# Altium schematic sheet Status_LEDS.SchDoc
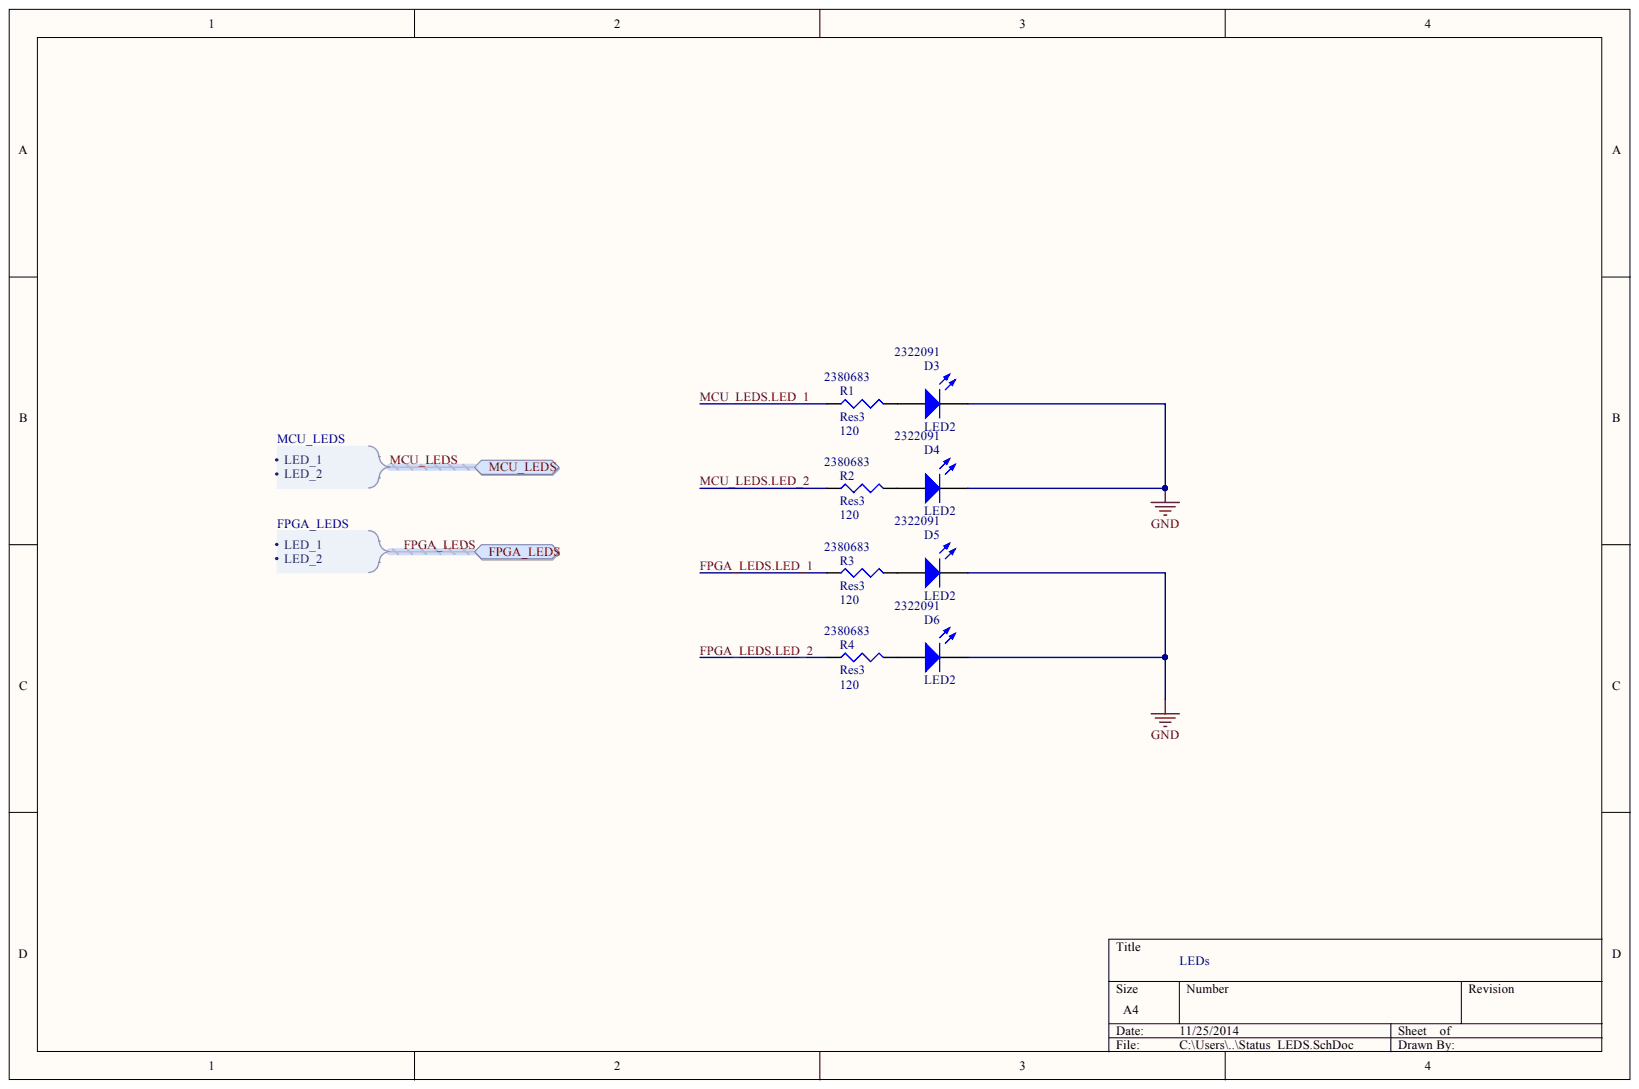
[diagram p0: Status_LEDS.SchDoc]

## Parts
D3  LED2  Supplier Part Number 1: 2322091  [C1206]
D4  LED2  Supplier Part Number 1: 2322091  [C1206]
D5  LED2  Supplier Part Number 1: 2322091  [C1206]
D6  LED2  Supplier Part Number 1: 2322091  [C1206]
R1  Res3  value 120  Supplier Part Number 1: 2380683  [14-1210]
R2  Res3  value 120  Supplier Part Number 1: 2380683  [14-1210]
R3  Res3  value 120  Supplier Part Number 1: 2380683  [14-1210]
R4  Res3  value 120  Supplier Part Number 1: 2380683  [14-1210]

## Ports
FPGA_LEDS, FPGA_LEDS.LED_1, FPGA_LEDS.LED_2, MCU_LEDS, MCU_LEDS.LED_1, MCU_LEDS.LED_2

## Nets
GND: D3.2 D4.2 D5.2 D6.2
FPGA_LEDS.LED_1: R3.1 ; port FPGA_LEDS
FPGA_LEDS.LED_2: R4.1 ; port FPGA_LEDS
MCU_LEDS.LED_1: R1.1 ; port MCU_LEDS
MCU_LEDS.LED_2: R2.1 ; port MCU_LEDS
NetD3_1: D3.1 R1.2
NetD4_1: D4.1 R2.2
NetD5_1: D5.1 R3.2
NetD6_1: D6.1 R4.2

## Library data of the parts
D3-D6 = LED2: Typical RED, GREEN, YELLOW, AMBER GaAs LED; Manufacturer: WURTH ELEKTRONIK; Manufacturer Part Number: 156120GS75300; Component Kind: Standard; ComponentLink1Description: http://katalog.we-online.de/led/datasheet/156120GS75300.pdf; ComponentLink1URL: http://katalog.we-online.de/led/datasheet/156120GS75300.pdf; LatestRevisionDate: 17-Jul-2002; LatestRevisionNote: Re-released for DXP Platform.; Library Name: Miscellaneous Devices.IntLib; PackageDescription: SM LED; 2 Flat Leads; PackageReference: 3.2X1.6X1.1; PackageVersion: Feb-2002; Pricing 1: 1=5,3, 50=4,9, 100=4,6, 500=3,65, 2000=3,3, 5000=2,95, 5000=2,65, 30000=2,4 (EUR); Published: 8-Jun-2000; RoHS: YES; Simulation: LED2; Stock 1: 15358; Supplier 1: Farnell; Publisher: Altium Limited
R1-R4 = Res3: Resistor; Manufacturer: PANASONIC; Manufacturer Part Number: ERJU14F1200U; Power Rating: 500; Product Range: PANASONIC - ERJ Series; Resistance Tolerance: ± 1%; Resistor Case Style: 1210 [3225 Metric]; Resistor Element Material: Thick Film; SVHC: No SVHC (16-Dec-2013; Temperature Coefficient: ± 100; Voltage Rating: 200; Component Kind: Standard; ComponentLink1Description: http://www.farnell.com/datasheets/1783557.pdf; ComponentLink1URL: http://www.farnell.com/datasheets/1783557.pdf; LatestRevisionDate: 29-May-2009; LatestRevisionNote: IPC-7351 Footprint Added.; Library Name: Miscellaneous Devices.IntLib; MSL: -; PackageDescription: Chip Resistor; PackageReference: J1-0603; Packaging: Cut Tape; Pricing 1: 10=1,05, 100=0,951, 500=0,734, 1000=0,589, 5000=0,434 (EUR); Published: 8-Jun-2000; Resistance: 120; RoHS: YES; Signal Integrity: Res; Simulation: RESISTOR; Stock 1: 2870; Supplier 1: Farnell; Publisher: Altium Limited
